# Altium schematic sheet SolderIronOut.SchDoc(SolderIronOut)
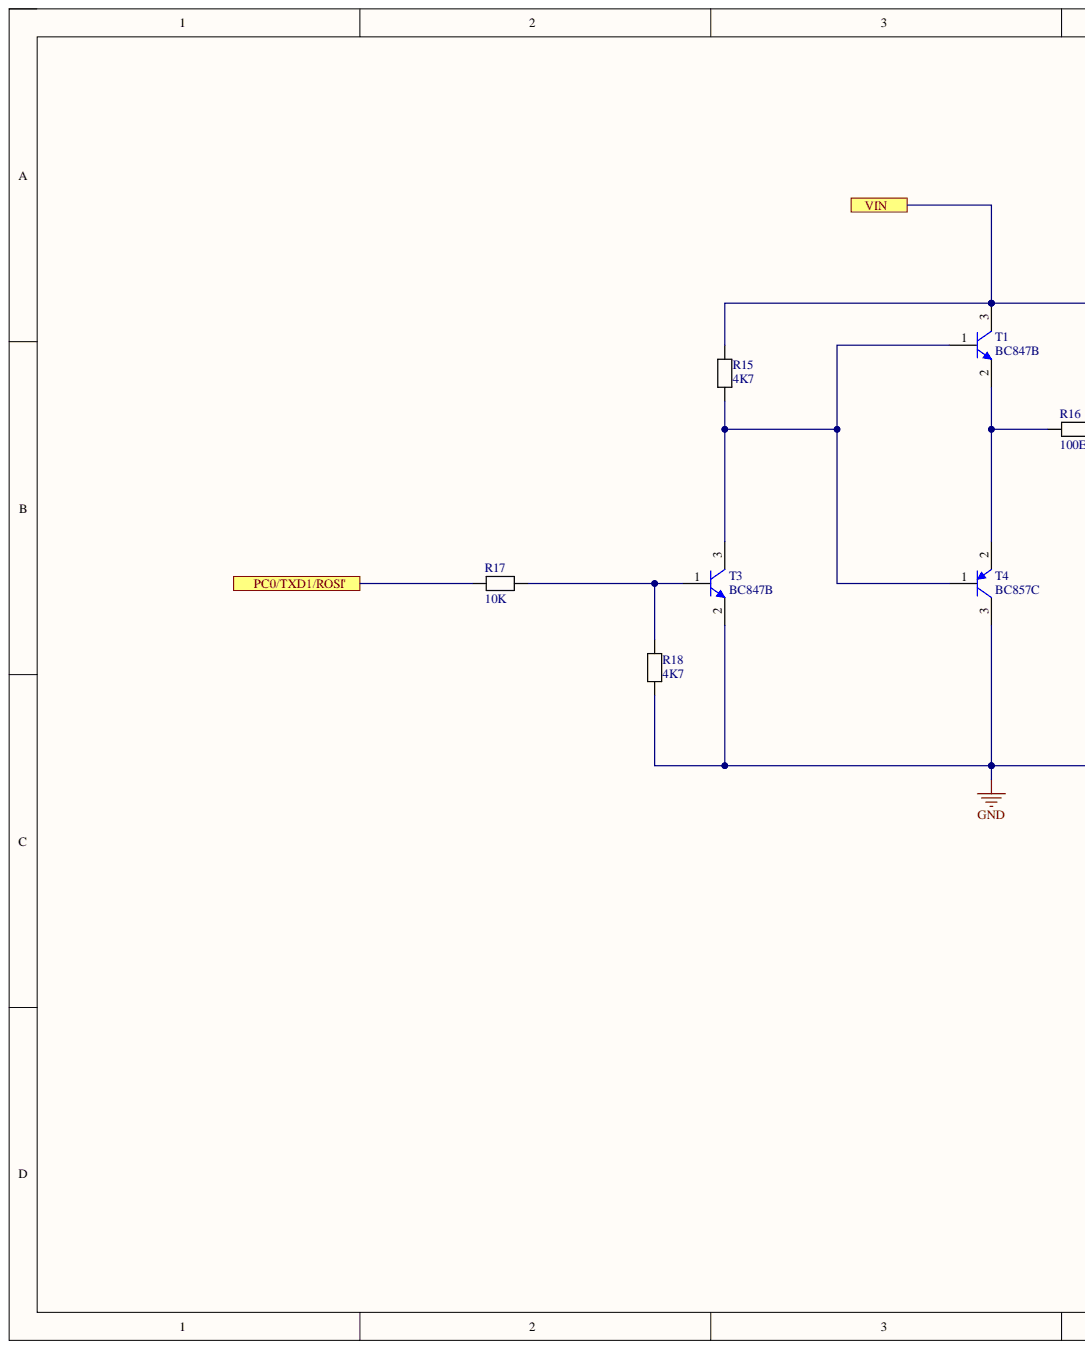
[diagram p0: SolderIronOut.SchDoc, left]
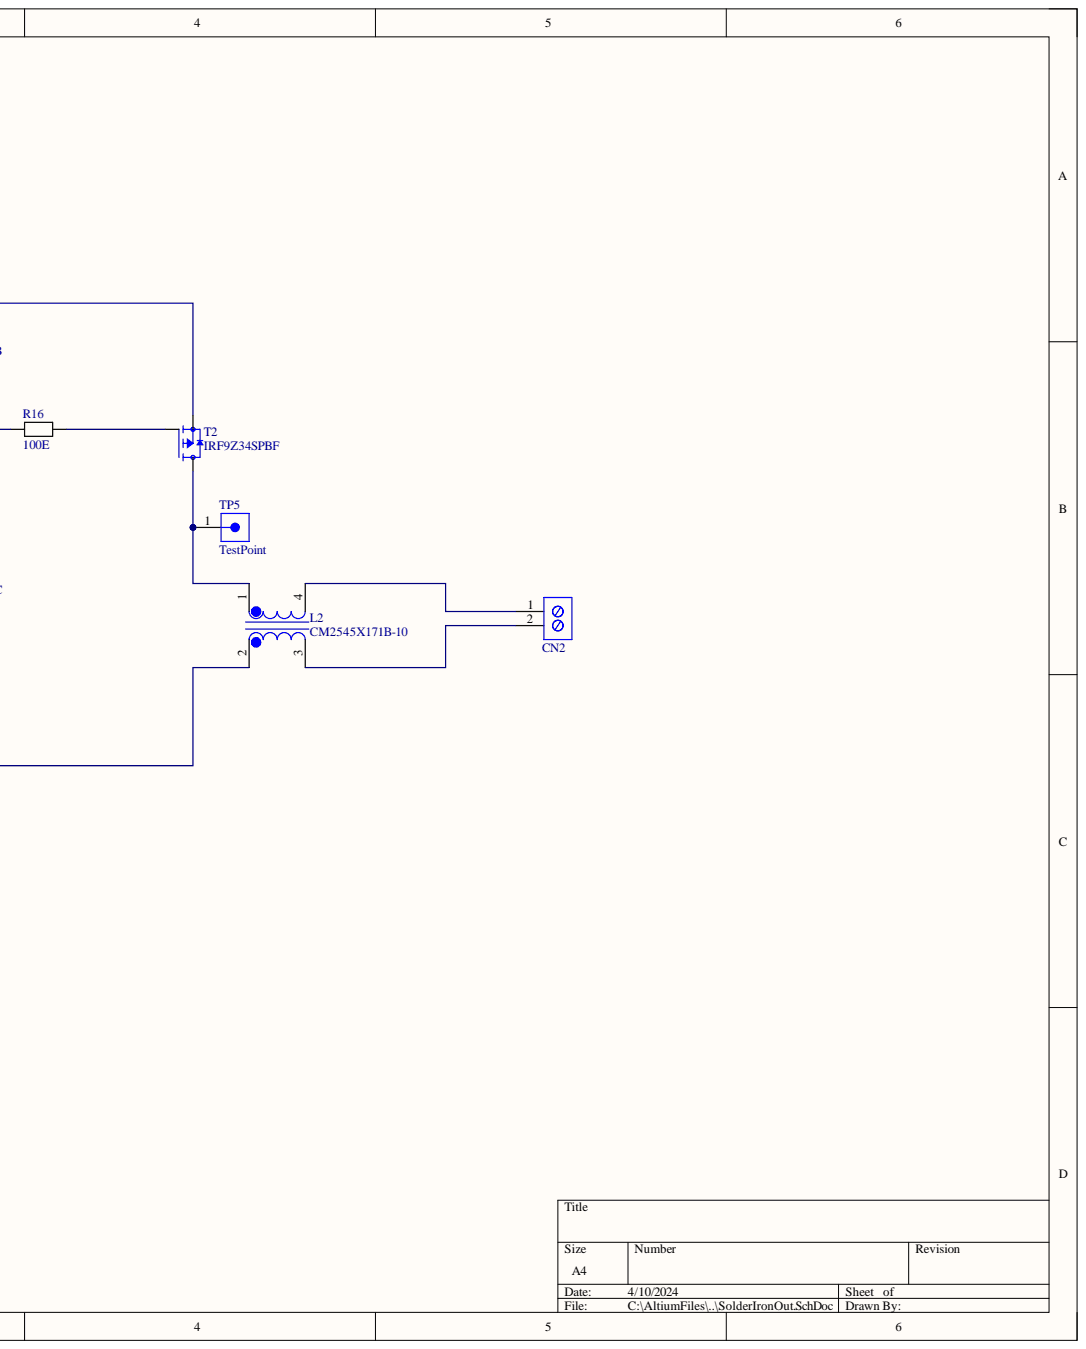
[diagram p1: SolderIronOut.SchDoc, right]

## Parts
CN2  Printkroonsteen 2 POL  [Printkroonsteen 2pin]  (value not drawn)
L2  CM2545X171B-10  [XFMR_CM2545X171B-10]
R15  4K7  [RESC2013X50X30LL20T20]
R16  100E  [RESC2013X50X30LL20T20]
R17  10K  [RESC2013X50X30LL20T20]
R18  4K7  [RESC2013X50X30LL20T20]
T1  BC847B  [INF-SOT23_L]
T2  IRF9Z34SPBF  [TO254P1521X483-4N]
T3  BC847B  [INF-SOT23_L]
T4  BC857C  [INF-SOT23_L]
TP5  TestPoint  [61300111121]

## Ports
PC0/TXD1/ROSI', VIN

## Nets
GND: L2.2 R18.1 T3.2 T4.3
PC0/TXD1/ROSI': R17.2 ; port PC0/TXD1/ROSI'
VIN: R15.2 T1.3 T2.3 ; port VIN
NetCN2_1: CN2.1 L2.4
NetCN2_2: CN2.2 L2.3
NetL2_1: L2.1 T2.4 TP5.1
NetR15_1: R15.1 T1.1 T3.3 T4.1
NetR16_1: R16.1 T2.1
NetR16_2: R16.2 T1.2 T4.2
NetR17_1: R17.1 R18.2 T3.1

## Library data of the parts
CN2 = printkroonsteen 1 X 2: Printkroonsteen 2 PO L1716020000; Manufacturer_Name: Weidmuller; Component Kind: Standard; Datasheet Link: https://catalog.weidmueller.com/catalog/Start.do?ObjectID=1716020000&_ga=2.21797499.1716783809.1613378202-511725545.1613378202; Library Name: SolderStation.SchLib; Manufacturer_Part_Number: 1716020000; Mouser Part Number: 470-1716020000; Mouser Price/Stock: https://www.mouser.co.uk/ProductDetail/Weidmuller/1716020000?qs=WJDKaGZ8K%252B7GSEFQgvMjVA%3D%3D
L2 = CM2545X171B-10: 2 Line Common Mode Choke Through Hole 170 Ohms @ 100 MHz 10A DCR 10mOhm; MF: Laird; Availability: In Stock; Check_prices: https://www.snapeda.com/parts/CM2545X171B-10/Laird/view-part/?ref=eda; Component Kind: Standard; Library Name: SolderStation.SchLib; MP: CM2545X171B-10; Package: Vertical-4 Laird; Price: None; Purchase-URL: https://pricing.snapeda.com/search/part/CM2545X171B-10/?ref=eda; SnapEDA_Link: https://www.snapeda.com/parts/CM2545X171B-10/Laird/view-part/?ref=snap
R15-R18 = resistor 0805: Manufacturer: Vishay Dale; Part Number: CRCW08050000Z0EA; Component Kind: Standard; Library Name: SolderStation.SchLib
T1 T3 = transistor BC847B NPN: NPN Silicon AF Transistor, 45 V VCEO, 100 mA IC, SOT23, Reel, Green CMP-1177-00042-1; Manufacturer: Infineon; fT (typ): 250Â MHz; Green: TRUE; IBM (max): 200Â mA; IC (max): 100Â mA; ICBO (max): 15Â nA; Ptot (max): 330Â mW; VCBO (max): 50Â V; VCEO (max): 45Â V; VEBO (max): 6Â V; Component Kind: Standard; ComponentLink1Description: Manufacturer URL; ComponentLink1URL: http://www.infineon.com/; ComponentLink2Description: Datasheet; ComponentLink2URL: http://www.infineon.com/dgdl/bc847series_bc848series_bc849series_bc850series.pdf?folderId=db3a304314dca389011541d30fa21656&fileId=db3a304314dca389011541d4630a1657; ComponentLink3Description: Package Specification; ComponentLink3URL: http://www.infineon.com/dgdl/SOT23-Package_Overview.pdf?folderId=db3a30431936bc4b0119532290053c66&fileId=db3a30431936bc4b0119533468123c85; ICM (max): 200Â mA; Library Name: SolderStation.SchLib; Mounting Technology: Surface Mount; PackageDescription: 3-Pin Small Outline Transistor Package, 1.3 x 2.9 mm Body, 0.95 mm Pitch; PackageReference: SOT23; PackageVersion: Apr-08; Packing: Reel; PartNumber: BC847B; Polarity: NPN
T2 = IRF9Z34SPBF: P-Channel 60V 18A _Tc_ 3.7W _Ta_, 88W _Tc_ Surface Mount D2PAK; MF: Vishay; Availability: Unavailable; Component Kind: Standard; Library Name: SolderStation.SchLib; MP: IRF9Z34SPBF; Package: D2PAK Vishay; Price: None; SnapEDA_Link: https://www.snapeda.com/parts/IRF9Z34SPBF/Vishay%20Siliconix/view-part/390212/?ref=snap
T4 = Transistor BC857C: PNP Silicon AF Transistor, 45 V VCEO, 100 mA IC, SOT23, Reel, Green CMP-1177-00039-1; Manufacturer: Infineon; fT (typ): 250Â MHz; Green: TRUE; IBM (max): 200Â mA; IC (max): 100Â mA; ICBO (max): 15Â nA; Ptot (max): 330Â mW; VCBO (max): 50Â V; VCEO (max): 45Â V; VEBO (max): 5Â V; Component Kind: Standard; ComponentLink1Description: Manufacturer URL; ComponentLink1URL: http://www.infineon.com/; ComponentLink2Description: Datasheet; ComponentLink2URL: http://www.infineon.com/dgdl/bc857series_bc858series_bc859series_bc860series.pdf?folderId=db3a304314dca389011541d30fa21656&fileId=db3a304314dca389011541da0e3a1661; ComponentLink3Description: Package Specification; ComponentLink3URL: http://www.infineon.com/dgdl/SOT23-Package_Overview.pdf?folderId=db3a30431936bc4b0119532290053c66&fileId=db3a30431936bc4b0119533468123c85; ICM (max): 200Â mA; Library Name: SolderStation.SchLib; Mounting Technology: Surface Mount; PackageDescription: 3-Pin Small Outline Transistor Package, 1.3 x 2.9 mm Body, 0.95 mm Pitch; PackageReference: SOT23; PackageVersion: Apr-08; Packing: Reel; PartNumber: BC857C; Polarity: PNP
TP5 = TestPoint: NexarPartId: 32855518; Connector Type: Male Pin; Contact Resistance: 20mΩ; Current Rating: 3A; Gender: Male; Number of Contacts: 1; Operating Supply Voltage: 250V; Color: Black; Component Kind: Standard; Contact Material: Copper; Contact Mating Length: 5.9944mm; Contact Plating: Gold; Lead Pitch: 2.54mm; Library Name: SolderStation.SchLib; Mount: Through Hole; Number of Pins: 1; Number of Positions: 1; Number of Rows: 1; Orientation: Vertical; Packaging: Bulk; REACH SVHC: Unknown; RoHS: Compliant; Termination: Solder
